# Altium schematic sheet HDMI_AUDIO.SchDoc(HDMI_AUDIO)
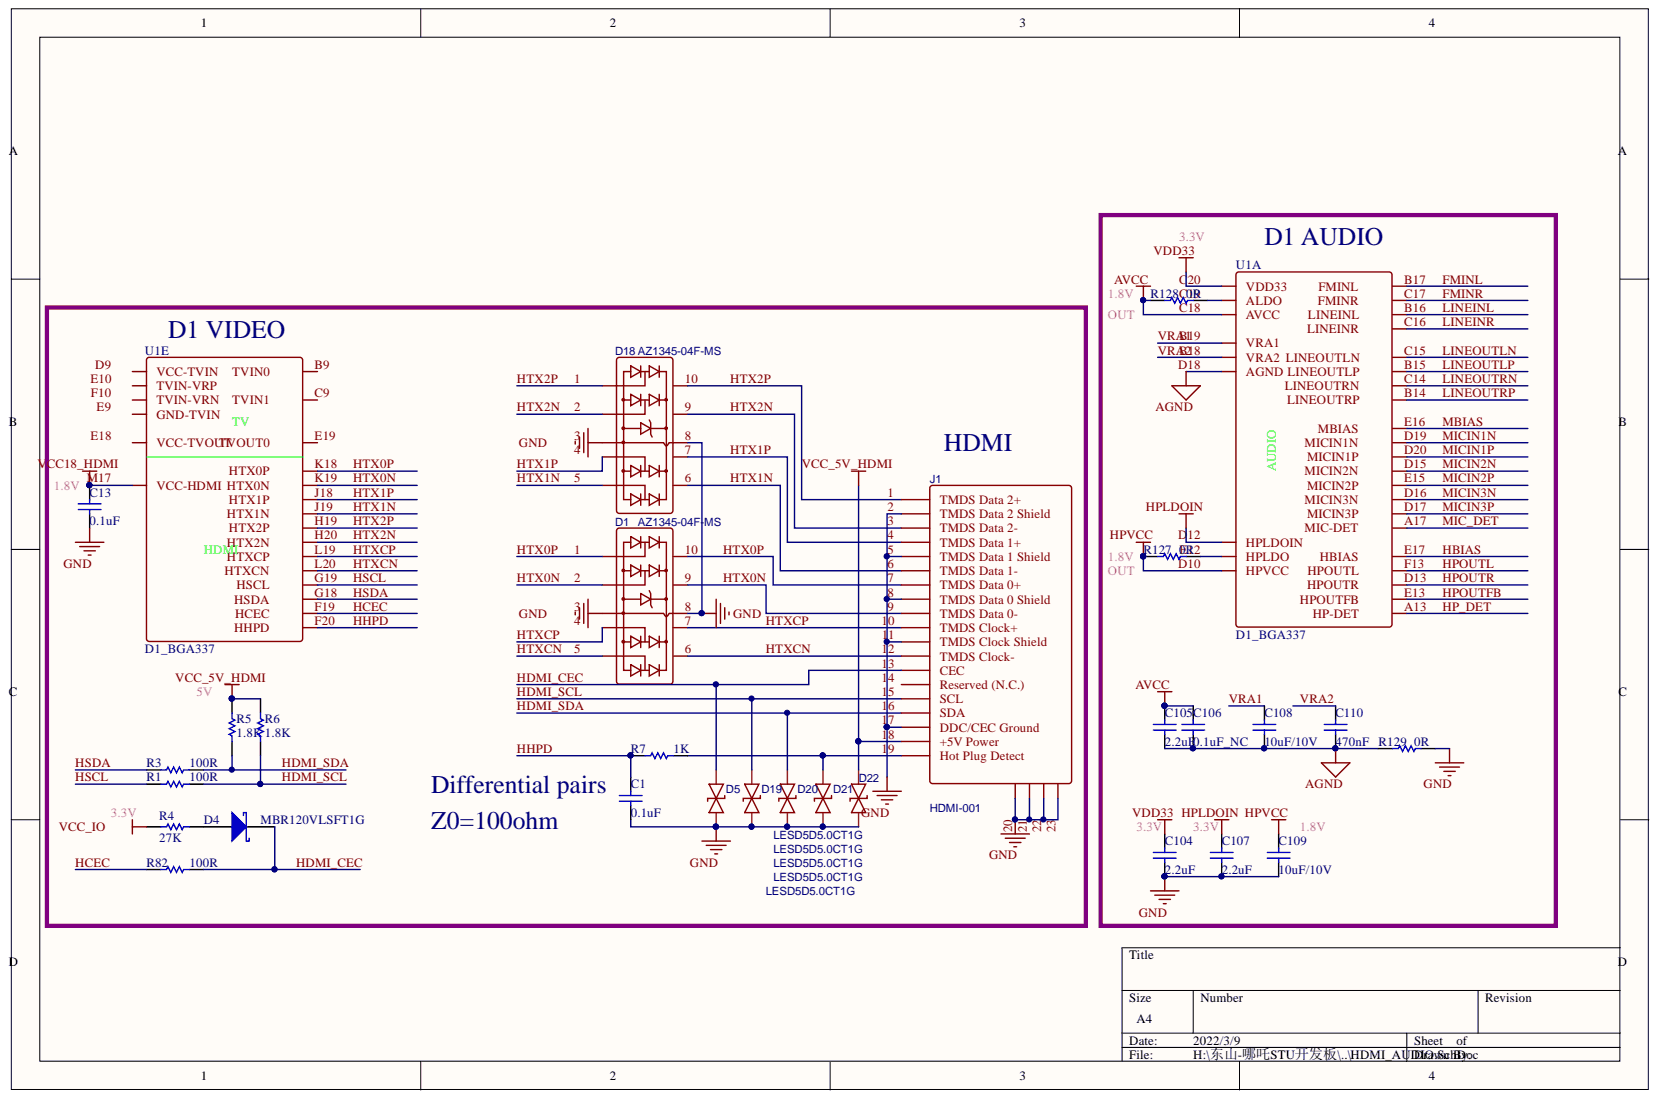
[diagram p0: HDMI_AUDIO.SchDoc]

## Parts
C1  0.1uF  [0402]
C13  0.1uF  [0402]
C104  2.2uF  [0402]
C105  2.2uF  [0402]
C106  0.1uF_NC  [0402]
C107  2.2uF  [0402]
C108  10uF/10V  [0603]
C109  10uF/10V  [0603]
C110  470nF  [0402]
D1  AZ1345-04F-MS  [DFN2510-10_L2.5-W1.0-P0.50-BL]
D4  MBR120VLSFT1G  [SOD-123_L2.8-W1.8-LS3.7-RD]
D5  LESD5D5.0CT1G  [SOD-523_L1.2-W0.8-LS1.6-BI]
D18  AZ1345-04F-MS  [DFN2510-10_L2.5-W1.0-P0.50-BL]
D19  LESD5D5.0CT1G  [SOD-523_L1.2-W0.8-LS1.6-BI]
D20  LESD5D5.0CT1G  [SOD-523_L1.2-W0.8-LS1.6-BI]
D21  LESD5D5.0CT1G  [SOD-523_L1.2-W0.8-LS1.6-BI]
D22  LESD5D5.0CT1G  [SOD-523_L1.2-W0.8-LS1.6-BI]
J1  HDMI-001  [HDMI-SMD_19P标准插座]
R1  100R  [0402]
R3  100R  [0402]
R4  27K  [0402]
R5  1.8K  [0402]
R6  1.8K  [0402]
R7  1K  [0402]
R82  100R  [0402]
R127  0R  [0402]
R128  0R  [0402]
R129  0R  [0402]
U1  D1_BGA337  (parts A,E)

## Nets
AGND: C105.2 C106.2 C108.2 C110.2 R129.2 U1.D18
AVCC: C105.1 C106.1 R128.2 U1.C18(AVCC)
GND: C1.2 C13.2 C104.2 C107.2 C109.2 D1.3 D1.8 D5.1 D18.3 D18.8 D19.1 D20.1 D21.1 D22.1 J1.2(TMDS_Data_2_Shield) J1.5(TMDS_Data_1_Shield) J1.8(TMDS_Data_0_Shield) J1.11(TMDS_Clock_Shield) J1.17(DDC/CEC_Ground) J1.20 J1.21 J1.22 J1.23 R129.1
HPLDOIN: C107.1 U1.D12(HPLDOIN)
HPVCC: C109.1 R127.2 U1.D10(HPVCC)
VCC18_HDMI: C13.1 U1.M17(VCC-HDMI)
VCC_5V_HDMI: D22.2 J1.18(+5V_Power) R5.1 R6.1
VCC_IO: R4.1
VDD33: C104.1 U1.C20(VDD33)
FMINL: U1.B17
FMINR: U1.C17
HBIAS: U1.E17
HCEC: R82.2 U1.F19
HDMI_CEC: D4.2 D5.2 J1.13(CEC) R82.1
HDMI_SCL: D19.2 J1.15(SCL) R1.1 R6.2
HDMI_SDA: D20.2 J1.16(SDA) R3.1 R5.2
HHPD: C1.1 R7.2 U1.F20
HP_DET: U1.A13
HPOUTFB: U1.E13
HPOUTL: U1.F13
HPOUTR: U1.D13
HSCL: R1.2 U1.G19
HSDA: R3.2 U1.G18
HTX0N: D1.2 D1.9 J1.9(TMDS_Data_0-) U1.K19
HTX0P: D1.1 D1.10 J1.7(TMDS_Data_0+) U1.K18
HTX1N: D18.5 D18.6 J1.6(TMDS_Data_1-) U1.J19
HTX1P: D18.4 D18.7 J1.4(TMDS_Data_1+) U1.J18
HTX2N: D18.2 D18.9 J1.3(TMDS_Data_2-) U1.H20
HTX2P: D18.1 D18.10 J1.1(TMDS_Data_2+) U1.H19
HTXCN: D1.5 D1.6 J1.12(TMDS_Clock-) U1.L20
HTXCP: D1.4 D1.7 J1.10(TMDS_Clock+) U1.L19
LINEINL: U1.B16
LINEINR: U1.C16
LINEOUTLN: U1.C15
LINEOUTLP: U1.B15
LINEOUTRN: U1.C14
LINEOUTRP: U1.B14
MBIAS: U1.E16
MIC_DET: U1.A17
MICIN1N: U1.D19
MICIN1P: U1.D20
MICIN2N: U1.D15
MICIN2P: U1.E15
MICIN3N: U1.D16
MICIN3P: U1.D17
VRA1: C108.1 U1.B19(VRA1)
VRA2: C110.1 U1.B18(VRA2)
NetD4_1: D4.1 R4.2
NetD21_2: D21.2 J1.19(Hot_Plug_Detect) R7.1
NetR127_1: R127.1 U1.E12(HPLDO)
NetR128_1: R128.1 U1.C19(ALDO)

Not connected: J1 14(Reserved_(N.C.)); U1 B9 C9 D9(VCC-TVIN) E9(GND-TVIN) E10(TVIN-VRP) E18(VCC-TVOUT) E19 F10(TVIN-VRN)

## Library data of the parts
C1 C13 C104-C110 = Cap: Capacitor; PartNumber: CAP100NF; Component Kind: Standard; LatestRevisionDate: 17-Jul-2002; LatestRevisionNote: Re-released for DXP Platform.; Library Name: Miscellaneous Devices.IntLib; PackageReference: 0402; Published: 8-Jun-2000; Signal Integrity: Cap; Simulation: CAP; Publisher: Altium Limited
  C1 C13: Value: 0.1uF
  C104 C105 C107: Value: 2.2uF
  C106: Value: 0.1uF_NC
  C108 C109: Value: 10uF/10V
  C110: Value: 470nF
D1 D18 = AZ1345-04F-MS: Contributor: LCSC; BOM_Manufacturer Part: AZ1345-04F-MS; BOM_Supplier: LCSC; BOM_Supplier Part: C2847056; Component Kind: Standard; spicePre: D; spiceSymbolName: AZ1345-04F-MS
D4 = D Schottky: Schottky Diode; Code_JEDEC: DO-214-AA; Component Kind: Standard; LatestRevisionDate: 17-Jul-2002; LatestRevisionNote: Re-released for DXP Platform.; Library Name: Miscellaneous Devices.IntLib; PackageDescription: DO-214-AA/SMB; 2 C-Bend Leads; Body 5.3 x 3.6 mm, inc. leads (LxW); PackageReference: SMB; Published: 8-Jun-2000; Signal Integrity: D Schottky; Simulation: SKYDIODE; Value: MBR120VLSFT1G; Publisher: Altium Limited
D5 D19-D22 = LESD5D5.0CT1G: BOM_Manufacturer: Leshan Radio; Contributor: LCSC; BOM_JLCPCB Part Class: Extended Part; BOM_Manufacturer Part: LESD5D5.0CT1G; BOM_Supplier: LCSC; BOM_Supplier Part: C383211; Component Kind: Standard; spicePre: D; spiceSymbolName: LESD5D5.0CT1G
J1 = HDMI-001: BOM_Manufacturer: Boom Precision Elec; Contributor: LCSC; BOM_JLCPCB Part Class: Extended Part; BOM_Manufacturer Part: HDMI-001; BOM_Supplier: LCSC; BOM_Supplier Part: C138388; Component Kind: Standard; Library Name: HM2.SchLib; spicePre: A; spiceSymbolName: HDMI-001
R1 R3-R7 R82 R127-R129 = Res1: Resistor; PartNumber: RES2.2K; Component Kind: Standard; LatestRevisionDate: 17-Jul-2002; LatestRevisionNote: Re-released for DXP Platform.; Library Name: Miscellaneous Devices.IntLib; PackageReference: 0402; Published: 8-Jun-2000; Signal Integrity: Res; Simulation: RESISTOR; Publisher: Altium Limited
  R1 R3 R82: Value: 100R
  R4: Value: 27K
  R5 R6: Value: 1.8K
  R7: Value: 1K
  R127-R129: Value: 0R
U1 = D1_BGA337: Component Kind: Standard; Library Name: HM2.SchLib
